# Altium schematic sheet OTL2_LED-Driver.SchDoc(OTL2_LED-Driver) (continued)

## Library data of the parts
5V_USB +3V3 +5V +48V DIM1 DIM2 RX TP1-TP11 TX = Test_Point: Library Name: josh-lib.SchLib
C1 C3 C5 = C1206 4.7uF 100V X7S: 100V 4.7uF X7S ±10% 1206 Multilayer Ceramic Capacitors MLCC - SMD/SMT ROHS; Manufacturer: Murata; Manufacturer Lifecycle Status: IN PRODUCTION; Manufacturer Part Number: GRM31CC72A475KE11L; Capacitance: 4.7µF; Case/Package: 1206; Category: Ceramic Capacitors; Component Kind: Standard; ComponentLink1Description: https://datasheet.octopart.com:443/GRM31CC72A475KE11L-Murata-datasheet-141280425.pdf?src-supplier=Geoflip; ComponentLink1URL: https://datasheet.octopart.com:443/GRM31CC72A475KE11L-Murata-datasheet-141280425.pdf?src-supplier=Geoflip; ComponentLink2Description: https://datasheet.octopart.com:443/GRM31CC72A475KE11L-Murata-datasheet-165579666.pdf?src-supplier=TME; ComponentLink2URL: https://datasheet.octopart.com:443/GRM31CC72A475KE11L-Murata-datasheet-165579666.pdf?src-supplier=TME; ComponentLink3Description: https://datasheet.octopart.com:443/GRM31CC72A475KE11L-Murata-datasheet-140709036.pdf?src-supplier=Ciiva; ComponentLink3URL: https://datasheet.octopart.com:443/GRM31CC72A475KE11L-Murata-datasheet-140709036.pdf?src-supplier=Ciiva; ComponentLink4Description: https://datasheet.octopart.com:443/GRM31CC72A475KE11L-Murata-datasheet-138746279.pdf?src-supplier=Ciiva; ComponentLink4URL: https://datasheet.octopart.com:443/GRM31CC72A475KE11L-Murata-datasheet-138746279.pdf?src-supplier=Ciiva; ComponentLink5Description: https://datasheet.octopart.com/GRM31CC72A475KE11L-Murata-datasheet-12515295.pdf; ComponentLink5URL: https://datasheet.octopart.com/GRM31CC72A475KE11L-Murata-datasheet-12515295.pdf; ComponentLink6Description: https://datasheet.octopart.com/GRM31CC72A475KE11L-Murata-datasheet-12510076.pdf; ComponentLink6URL: https://datasheet.octopart.com/GRM31CC72A475KE11L-Murata-datasheet-12510076.pdf; ComponentLink7Description: https://datasheet.octopart.com/GRM31CC72A475KE11L-Murata-datasheet-11978611.pdf; ComponentLink7URL: https://datasheet.octopart.com/GRM31CC72A475KE11L-Murata-datasheet-11978611.pdf; ComponentLink8Description: https://datasheet.octopart.com/GRM31CC72A475KE11L-Murata-datasheet-10025737.pdf; ComponentLink8URL: https://datasheet.octopart.com/GRM31CC72A475KE11L-Murata-datasheet-10025737.pdf; ComponentLink9Description: https://datasheet.octopart.com/GRM31CC72A475KE11L-Murata-datasheet-8819587.pdf; ComponentLink9URL: https://datasheet.octopart.com/GRM31CC72A475KE11L-Murata-datasheet-8819587.pdf; ComponentLink10Description: http://sigma.octopart.com:80/reach_statement/Murata-company-16.pdf?src-supplier=Compliance+Statements; ComponentLink10URL: http://sigma.octopart.com:80/reach_statement/Murata-company-16.pdf?src-supplier=Compliance+Statements; ComponentLink11Description: LCSC; ComponentLink11URL: https://jlcpcb.com/partdetail/236748-GRM31CC72A475KE11L/C237304; Dielectric: X7S; Dielectric Material: Ceramic; Export Control Classification Number (ECCN) Code: EAR99; Harmonized Tariff Schedule (HTS) Code: 8532.24.00.20; Height: 1.6mm; Introduction Date: 2017-02-22; Length: 3.2mm; Lifecycle Status: Production; Material: Ceramic; Max Operating Temperature: 125°C; Min Operating Temperature: -55°C; Mount: Surface Mount; Number of Terminals: 2; Pricing 1: 2000=0.16105 (USD); REACH SVHC: Yes; RoHS: Compliant; Schedule B: 8532240020, 8532240020|8532240020|8532240020|8532240020|8532240020|8532240020, 8532240020|8532240020|8532240020|8532240020|8532240020|8532240020|8532240020, 8532240020|8532240020|8532240020|8532240020|8532240020|8532240020|8532240020|8532240020; Stock 1: 66000; Supplier: LCSC; Supplier 1: Avnet; Supplier Part Number: C237304; Supplier Part Number 1: GRM31CC72A475KE11L; Thickness: 1.6mm; Tolerance: 10%; Voltage Rating (DC): 100V; Width: 1.6mm; Library Name: josh-lib.SchLib
C2 C4 C12 C16 C17 = CL05A105KA5NQNC: 1 µF ±10% 25V Ceramic Capacitor X5R 0402 (1005 Metric); Manufacturer: Samsung; Capacitance: 1uF; Case Code (Imperial): 0402; Case/Package: 0402; Category: Ceramic Capacitors; Component Kind: Standard; ComponentLink6Description: LCSC; ComponentLink6URL: https://lcsc.com/product-detail/Multilayer-Ceramic-Capacitors-MLCC-SMD-SMT_Samsung-Electro-Mechanics-CL05A105KA5NQNC_C52923.html; Depth: 0.5mm; Dielectric: X5R; Dielectric Material: Ceramic; Height: 0.5mm; Lead Free: Lead Free; Lead Pitch: 0.3mm; Length: 1mm; Manufacturer Part Number: CL05A105KA5NQNC; Max Operating Temperature: 85°C; Min Operating Temperature: -55°C; Mount: Surface Mount; Number of Pins: 2; Package Quantity: 1; Packaging: Tape and Reel; Radiation Hardening: No; REACH SVHC: No SVHC; RoHS: Yes; Supplier: LCSC; Supplier 1: Arrow Electronics; Supplier Part Number: C52923; Supplier Part Number 1: CL05A105KA5NQNC; Termination: SMD/SMT; Thickness: 0.022inch; Tolerance: 10%; Width: 0.02inch; Library Name: josh-lib.SchLib
  C12 C16 C17: Voltage Rating: 25V
C6 C10 C13 C14 C21 C22 = CL05B104KO5NNNC: 16V 100nF X7R ±10% 0402 Multilayer Ceramic Capacitors MLCC - SMD/SMT ROHS; Manufacturer: Samsung Electro-Mechanics; Capacitance: 100nF; Case Code (Imperial): 0402; Case Code (Metric): 1005; Case/Package: 0402; Category: Ceramic Capacitors; China RoHS: Compliant; Component Kind: Standard; ComponentLink1Description: https://datasheet.octopart.com:443/CL05B104KO5NNNC-Samsung-Electro-Mechanics-datasheet-181960518.pdf?src-supplier=IHS; ComponentLink1URL: https://datasheet.octopart.com:443/CL05B104KO5NNNC-Samsung-Electro-Mechanics-datasheet-181960518.pdf?src-supplier=IHS; ComponentLink2Description: https://datasheet.octopart.com:443/CL05B104KO5NNNC-Samsung-Electro-Mechanics-datasheet-81461623.pdf?src-supplier=Upverter; ComponentLink2URL: https://datasheet.octopart.com:443/CL05B104KO5NNNC-Samsung-Electro-Mechanics-datasheet-81461623.pdf?src-supplier=Upverter; ComponentLink3Description: https://datasheet.octopart.com/CL05B104KO5NNNC-Samsung-Electro-Mechanics-datasheet-8324245.pdf; ComponentLink3URL: https://datasheet.octopart.com/CL05B104KO5NNNC-Samsung-Electro-Mechanics-datasheet-8324245.pdf; ComponentLink4Description: https://datasheet.octopart.com/CL05B104KO5NNNC-Samsung-Electro-Mechanics-datasheet-97040617.pdf; ComponentLink4URL: https://datasheet.octopart.com/CL05B104KO5NNNC-Samsung-Electro-Mechanics-datasheet-97040617.pdf; ComponentLink5Description: https://datasheet.octopart.com:443/CL05B104KO5NNNC-Samsung-Electro-Mechanics-datasheet-141346863.pdf?src-supplier=Samsung+SCT; ComponentLink5URL: https://datasheet.octopart.com:443/CL05B104KO5NNNC-Samsung-Electro-Mechanics-datasheet-141346863.pdf?src-supplier=Samsung+SCT; ComponentLink6Description: https://datasheet.octopart.com:443/CL05B104KO5NNNC-Samsung-datasheet-151956582.pdf?src-supplier=SOS+electronic; ComponentLink6URL: https://datasheet.octopart.com:443/CL05B104KO5NNNC-Samsung-datasheet-151956582.pdf?src-supplier=SOS+electronic; ComponentLink7Description: https://datasheet.octopart.com:443/CL05B104KO5NNNC-Samsung-datasheet-42485123.pdf?src-supplier=Future+Electronics; ComponentLink7URL: https://datasheet.octopart.com:443/CL05B104KO5NNNC-Samsung-datasheet-42485123.pdf?src-supplier=Future+Electronics; ComponentLink8Description: https://datasheet.octopart.com:443/CL05B104KO5NNNC-Samsung-datasheet-34153835.pdf?src-supplier=Future+Electronics; ComponentLink8URL: https://datasheet.octopart.com:443/CL05B104KO5NNNC-Samsung-datasheet-34153835.pdf?src-supplier=Future+Electronics; ComponentLink9Description: https://datasheet.octopart.com:443/CL05B104KO5NNNC-Samsung-datasheet-26589024.pdf?src-supplier=Future+Electronics; ComponentLink9URL: https://datasheet.octopart.com:443/CL05B104KO5NNNC-Samsung-datasheet-26589024.pdf?src-supplier=Future+Electronics; ComponentLink10Description: https://datasheet.octopart.com:443/CL05B104KO5NNNC-Samsung-Electro-Mechanics-datasheet-149136714.pdf?src-supplier=Future+Electronics; ComponentLink10URL: https://datasheet.octopart.com:443/CL05B104KO5NNNC-Samsung-Electro-Mechanics-datasheet-149136714.pdf?src-supplier=Future+Electronics; ComponentLink11Description: https://datasheet.octopart.com:443/CL05B104KO5NNNC-Samsung-datasheet-59220082.pdf?src-supplier=TME; ComponentLink11URL: https://datasheet.octopart.com:443/CL05B104KO5NNNC-Samsung-datasheet-59220082.pdf?src-supplier=TME; ComponentLink12Description: LCSC; ComponentLink12URL: https://jlcpcb.com/partdetail/1877-CL05B104KO5NNNC/C1525; Contact Plating: Tin; Depth: 500µm; Dielectric: X7R; Dielectric Material: Ceramic; Height: 500µm; Lead Free: Lead Free; Length: 1mm; Lifecycle Status: Production; Manufacturer Part Number: CL05B104KO5NNNC; Material: Ceramic; Max Operating Temperature: 125°C; Min Operating Temperature: -55°C; Mount: Surface Mount; Number of Terminals: 2; Pricing 1: 10000=0.00139, 20000=0.00137, 40000=0.00135, 80000=0.00133, 160000=0.00131 (USD); Radiation Hardening: No; REACH SVHC: Yes; RoHS: Compliant; Schedule B: 8532240020, 8532240020|8532240020|8532240020|8532240020|8532240020|8532240020|8532240020|8532240020|8532240020|8532240020|8532240020|8532240020|8532240020|8532240020|8532240020|8532240020|8532240020|8532240020|8532240020|8532240020|8532240020|8532240020|8532240020|85; Stock 1: 1520000; Supplier: LCSC; Supplier 1: Avnet; Supplier Part Number: C1525; Supplier Part Number 1: CL05B104KO5NNNC; Thickness: 558.8µm; Tolerance: 10%; Voltage: 16V; Voltage Rating: 16V; Voltage Rating (DC): 16V; Width: 500µm; Library Name: josh-lib.SchLib
C7 = CA45-A-16V-10μF-K: 10uF 16V 2Ω@100kHz ±10% CASE-A-3216 Tantalum Capacitors ROHS; Manufacturer: TORCH; Value: 10uF 16V CASE-A-3216; Component Kind: Standard; ComponentLink1Description: LCSC; ComponentLink1URL: https://www.lcsc.com/product-detail/Tantalum-Capacitors_TORCH-CA45-A-16V-10-F-K_C185774.html; Manufacturer Part Number: CA45-A-16V-10μF-K; Supplier: LCSC; Supplier Part Number: C185774; Library Name: josh-lib.SchLib
C8 C9 = C0805 22uF 25V X5R: Multilayer Ceramic Capacitor, 22 uF, 25 V, ± 20%, X5R, 0805 [2012 Metric]; Manufacturer: Samsung Electro-Mechanics; Manufacturer Part Number: CL21A226MAQNNNE; Capacitance: 22µF; Voltage Rating: 25V; Case Code (Imperial): 0805; Case Code (Metric): 2012; Case/Package: 0805; Category: Ceramic Capacitors; China RoHS: Compliant; Component Kind: Standard; ComponentLink1Description: https://datasheet.octopart.com:443/CL21A226MAQNNNE-Samsung-Electro-Mechanics-datasheet-182022794.pdf?src-supplier=IHS; ComponentLink1URL: https://datasheet.octopart.com:443/CL21A226MAQNNNE-Samsung-Electro-Mechanics-datasheet-182022794.pdf?src-supplier=IHS; ComponentLink2Description: https://datasheet.octopart.com/CL21A226MAQNNNE-Samsung-Electro-Mechanics-datasheet-97040617.pdf; ComponentLink2URL: https://datasheet.octopart.com/CL21A226MAQNNNE-Samsung-Electro-Mechanics-datasheet-97040617.pdf; ComponentLink3Description: https://datasheet.octopart.com/CL21A226MAQNNNE-Samsung-Electro-Mechanics-datasheet-8324245.pdf; ComponentLink3URL: https://datasheet.octopart.com/CL21A226MAQNNNE-Samsung-Electro-Mechanics-datasheet-8324245.pdf; ComponentLink4Description: https://datasheet.octopart.com/General-Multilayer-Ceramic-Capacitors-Samsung-datasheet-family-111.pdf; ComponentLink4URL: https://datasheet.octopart.com/General-Multilayer-Ceramic-Capacitors-Samsung-datasheet-family-111.pdf; ComponentLink5Description: https://datasheet.octopart.com:443/CL21A226MAQNNNE-Samsung-Electro-Mechanics-datasheet-87580133.pdf?src-supplier=Future+Electronics; ComponentLink5URL: https://datasheet.octopart.com:443/CL21A226MAQNNNE-Samsung-Electro-Mechanics-datasheet-87580133.pdf?src-supplier=Future+Electronics; ComponentLink6Description: https://datasheet.octopart.com:443/CL21A226MAQNNNE-Samsung-Electro-Mechanics-datasheet-81462139.pdf?src-supplier=Future+Electronics; ComponentLink6URL: https://datasheet.octopart.com:443/CL21A226MAQNNNE-Samsung-Electro-Mechanics-datasheet-81462139.pdf?src-supplier=Future+Electronics; ComponentLink7Description: https://datasheet.octopart.com:443/CL21A226MAQNNNE-Samsung-datasheet-66660282.pdf?src-supplier=Future+Electronics; ComponentLink7URL: https://datasheet.octopart.com:443/CL21A226MAQNNNE-Samsung-datasheet-66660282.pdf?src-supplier=Future+Electronics; ComponentLink8Description: https://datasheet.octopart.com:443/CL21A226MAQNNNE-Samsung-datasheet-48647558.pdf?src-supplier=Future+Electronics; ComponentLink8URL: https://datasheet.octopart.com:443/CL21A226MAQNNNE-Samsung-datasheet-48647558.pdf?src-supplier=Future+Electronics; ComponentLink9Description: https://datasheet.octopart.com:443/CL21A226MAQNNNE-Samsung-datasheet-27135701.pdf?src-supplier=Future+Electronics; ComponentLink9URL: https://datasheet.octopart.com:443/CL21A226MAQNNNE-Samsung-datasheet-27135701.pdf?src-supplier=Future+Electronics; ComponentLink10Description: https://datasheet.octopart.com:443/CL21A226MAQNNNE-Samsung-Electro-Mechanics-datasheet-149154242.pdf?src-supplier=Future+Electronics; ComponentLink10URL: https://datasheet.octopart.com:443/CL21A226MAQNNNE-Samsung-Electro-Mechanics-datasheet-149154242.pdf?src-supplier=Future+Electronics; ComponentLink11Description: https://datasheet.octopart.com:443/CL21A226MAQNNNE-Samsung-datasheet-59220082.pdf?src-supplier=TME; ComponentLink11URL: https://datasheet.octopart.com:443/CL21A226MAQNNNE-Samsung-datasheet-59220082.pdf?src-supplier=TME; ComponentLink12Description: http://sigma.octopart.com:80/rohs_certificate/General-Multilayer-Ceramic-Capacitors-Samsung-family-110.pdf?src-supplier=Compliance+Statements; ComponentLink12URL: http://sigma.octopart.com:80/rohs_certificate/General-Multilayer-Ceramic-Capacitors-Samsung-family-110.pdf?src-supplier=Compliance+Statements; ComponentLink13Description: http://sigma.octopart.com:80/rohs_statement/General-Multilayer-Ceramic-Capacitors-Samsung-family-132.pdf?src-supplier=Compliance+Statements; ComponentLink13URL: http://sigma.octopart.com:80/rohs_statement/General-Multilayer-Ceramic-Capacitors-Samsung-family-132.pdf?src-supplier=Compliance+Statements; ComponentLink14Description: http://sigma.octopart.com:80/reach_statement/General-Multilayer-Ceramic-Capacitors-Samsung-family-133.pdf?src-supplier=Compliance+Statements; ComponentLink14URL: http://sigma.octopart.com:80/reach_statement/General-Multilayer-Ceramic-Capacitors-Samsung-family-133.pdf?src-supplier=Compliance+Statements; ComponentLink15Description: LCSC; ComponentLink15URL: https://jlcpcb.com/partdetail/46786-CL21A226MAQNNNE/C45783; Contact Plating: Tin; Country of Origin: Mainland China, Philippines, South Korea; Depth: 1.25mm; Dielectric: X5R; Dielectric Material: Ceramic; Export Control Classification Number (ECCN) Code: EAR99; Harmonized Tariff Schedule (HTS) Code: 8532.24.00.20; Height: 1.25mm; Introduction Date: 2012-03-06; Length: 2mm; Lifecycle Status: NRND; Max Operating Temperature: 85°C; Min Operating Temperature: -55°C; Mount: Surface Mount; Number of Terminals: 2; Packaging: Cut Tape (CT); Pricing 1: 5=0.107, 50=0.0619, 250=0.0615, 500=0.0611, 1000=0.0607, 2000=0.0603 (GBP); REACH SVHC: Yes; RoHS: Compliant; Supplier: LCSC; Supplier 1: Farnell; Supplier Part Number: C45783; Supplier Part Number 1: 3013459; Termination: Wraparound; Thickness: 1.397mm; Tolerance: 20%; Voltage Rating (DC): 25V; Width: 1.25mm; Library Name: josh-lib.SchLib
C11 = CL21A226MOQNNNE: Cap Ceramic 22uF 16V X5R 20% SMD 0805 85°C Embossed Plastic T/R; Manufacturer: Samsung; Capacitance: 22uF; Case Code (Imperial): 0805; Case Code (Metric): 2012; Case/Package: 0805; Category: Ceramic Capacitors; Component Kind: Standard; ComponentLink1Description: https://datasheet.ciiva.com/25724/cl21a226moqnnne-25724832.pdf?src-supplier=Digi-Key; ComponentLink1URL: https://datasheet.ciiva.com/25724/cl21a226moqnnne-25724832.pdf?src-supplier=Digi-Key; ComponentLink2Description: LCSC; ComponentLink2URL: https://www.lcsc.com/product-detail/Multilayer-Ceramic-Capacitors-MLCC-SMD-SMT_Samsung-Electro-Mechanics-CL21A226MOQNNNE_C98190.html; Dielectric: X5R; Height: 1.45mm; Lead Free: Lead Free; Length: 0.079inch; Manufacturer Part Number: CL21A226MOQNNNE; Material: Ceramic; Max Operating Temperature: 85°C; Min Operating Temperature: -55°C; Mount: Surface Mount; Package Quantity: 1; Packaging: Cut Tape; Pricing 1: 2000=0.052, 4000=0.0513, 10000=0.0472, 14000=0.0431, 50000=0.0375, 100000=0.0327 (USD); RoHS: Yes; RoHS Compliant: Yes; Series: CL; Stock 1: 884000; Supplier: LCSC; Supplier 1: Arrow Electronics; Supplier Part Number: C98190; Supplier Part Number 1: CL21A226MOQNNNE; Thickness: 0.055inch; Tolerance: 20%; Value: 22uF 16V X5R; Voltage Rating: 16V; Voltage Rating (DC): 16V; Width: 0.049inch; Library Name: josh-lib.SchLib
C15 = C0402 10nF 50V X7R JLC: 50V 10nF X7R ±10% 0402 Multilayer Ceramic Capacitors MLCC - SMD/SMT ROHS; Manufacturer: Samsung Electro-Mechanics; Manufacturer Part Number: CL05B103KB5NNNC; Capacitance: 10nF; Case Code (Imperial): 0402; Case Code (Metric): 1005; Case/Package: 0402; Category: Ceramic Capacitors; China RoHS: Compliant; Component Kind: Standard; ComponentLink1Description: https://datasheet.octopart.com:443/CL05B103KB5NNNC-Samsung-Electro-Mechanics-datasheet-136482191.pdf?src-supplier=IHS; ComponentLink1URL: https://datasheet.octopart.com:443/CL05B103KB5NNNC-Samsung-Electro-Mechanics-datasheet-136482191.pdf?src-supplier=IHS; ComponentLink2Description: https://datasheet.octopart.com/CL05B103KB5NNNC-Samsung-Electro-Mechanics-datasheet-8324245.pdf; ComponentLink2URL: https://datasheet.octopart.com/CL05B103KB5NNNC-Samsung-Electro-Mechanics-datasheet-8324245.pdf; ComponentLink3Description: https://datasheet.octopart.com/CL05B103KB5NNNC-Samsung-Electro-Mechanics-datasheet-97040617.pdf; ComponentLink3URL: https://datasheet.octopart.com/CL05B103KB5NNNC-Samsung-Electro-Mechanics-datasheet-97040617.pdf; ComponentLink4Description: https://datasheet.octopart.com:443/CL05B103KB5NNNC-Samsung-Electro-Mechanics-datasheet-141346848.pdf?src-supplier=Samsung+SCT; ComponentLink4URL: https://datasheet.octopart.com:443/CL05B103KB5NNNC-Samsung-Electro-Mechanics-datasheet-141346848.pdf?src-supplier=Samsung+SCT; ComponentLink5Description: https://datasheet.octopart.com:443/CL05B103KB5NNNC-Samsung-Electro-Mechanics-datasheet-117987511.pdf?src-supplier=Future+Electronics; ComponentLink5URL: https://datasheet.octopart.com:443/CL05B103KB5NNNC-Samsung-Electro-Mechanics-datasheet-117987511.pdf?src-supplier=Future+Electronics; ComponentLink6Description: https://datasheet.octopart.com:443/CL05B103KB5NNNC-Samsung-Electro-Mechanics-datasheet-81461618.pdf?src-supplier=Future+Electronics; ComponentLink6URL: https://datasheet.octopart.com:443/CL05B103KB5NNNC-Samsung-Electro-Mechanics-datasheet-81461618.pdf?src-supplier=Future+Electronics; ComponentLink7Description: https://datasheet.octopart.com:443/CL05B103KB5NNNC-Samsung-datasheet-44382835.pdf?src-supplier=Future+Electronics; ComponentLink7URL: https://datasheet.octopart.com:443/CL05B103KB5NNNC-Samsung-datasheet-44382835.pdf?src-supplier=Future+Electronics; ComponentLink8Description: https://datasheet.octopart.com:443/CL05B103KB5NNNC-Samsung-datasheet-26589021.pdf?src-supplier=Future+Electronics; ComponentLink8URL: https://datasheet.octopart.com:443/CL05B103KB5NNNC-Samsung-datasheet-26589021.pdf?src-supplier=Future+Electronics; ComponentLink9Description: https://datasheet.octopart.com:443/CL05B103KB5NNNC-Samsung-Electro-Mechanics-datasheet-149136708.pdf?src-supplier=Future+Electronics; ComponentLink9URL: https://datasheet.octopart.com:443/CL05B103KB5NNNC-Samsung-Electro-Mechanics-datasheet-149136708.pdf?src-supplier=Future+Electronics; ComponentLink10Description: https://datasheet.octopart.com:443/CL05B103KB5NNNC-Samsung-datasheet-59220082.pdf?src-supplier=TME; ComponentLink10URL: https://datasheet.octopart.com:443/CL05B103KB5NNNC-Samsung-datasheet-59220082.pdf?src-supplier=TME; ComponentLink11Description: https://datasheet.octopart.com:443/CL05B103KB5NNNC-Samsung-Electro-Mechanics-datasheet-11773140.pdf?src-supplier=Arrow+Electronics; ComponentLink11URL: https://datasheet.octopart.com:443/CL05B103KB5NNNC-Samsung-Electro-Mechanics-datasheet-11773140.pdf?src-supplier=Arrow+Electronics; ComponentLink12Description: JLCPCB; ComponentLink12URL: https://jlcpcb.com/partdetail/15869-CL05B103KB5NNNC/C15195; Contact Plating: Tin; Depth: 500µm; Dielectric: X7R; Dielectric Material: Ceramic; Height: 550µm; Lead Free: Lead Free; Length: 1mm; Lifecycle Status: Production; Material: Ceramic; Max Operating Temperature: 125°C; Min Operating Temperature: -55°C; Mount: Surface Mount; Number of Terminals: 2; Pricing 1: 80000=0.0013 (USD); Radiation Hardening: No; REACH SVHC: Yes; RoHS: Compliant; Schedule B: 8532240020, 8532240020|8532240020, 8532240020|8532240020|8532240020, 8532240020|8532240020|8532240020|8532240020; Stock 1: 9520000; Supplier: LCSC; Supplier 1: Arrow Electronics; Supplier Part Number: C15195; Supplier Part Number 1: CL05B103KB5NNNC; Thickness: 558.8µm; Tolerance: 10%; Voltage: 50V; Voltage Rating: 50V; Voltage Rating (DC): 50V; Width: 500µm; Library Name: josh-lib.SchLib
C18 = C0805 100nF 100V X7R: 100V 100nF X7R ±10% 0805 Multilayer Ceramic Capacitors MLCC - SMD/SMT ROHS; Manufacturer: Samsung Electro-Mechanics; Manufacturer Part Number: CL21B104KCFNNNE; Capacitance: 100nF; Case Code (Imperial): 0805; Case Code (Metric): 2012; Case/Package: 0805; Category: Ceramic Capacitors; China RoHS: Compliant; Component Kind: Standard; ComponentLink1Description: https://datasheet.octopart.com:443/CL21B104KCFNNNE-Samsung-Electro-Mechanics-datasheet-136482490.pdf?src-supplier=IHS; ComponentLink1URL: https://datasheet.octopart.com:443/CL21B104KCFNNNE-Samsung-Electro-Mechanics-datasheet-136482490.pdf?src-supplier=IHS; ComponentLink2Description: https://datasheet.octopart.com:443/CL21B104KCFNNNE-Samsung-Electro-Mechanics-datasheet-116891036.pdf?src-supplier=Future+Electronics; ComponentLink2URL: https://datasheet.octopart.com:443/CL21B104KCFNNNE-Samsung-Electro-Mechanics-datasheet-116891036.pdf?src-supplier=Future+Electronics; ComponentLink3Description: https://datasheet.octopart.com:443/CL21B104KCFNNNE-Samsung-Electro-Mechanics-datasheet-68302338.pdf?src-supplier=Future+Electronics; ComponentLink3URL: https://datasheet.octopart.com:443/CL21B104KCFNNNE-Samsung-Electro-Mechanics-datasheet-68302338.pdf?src-supplier=Future+Electronics; ComponentLink4Description: https://datasheet.octopart.com:443/CL21B104KCFNNNE-Samsung-datasheet-66660282.pdf?src-supplier=Future+Electronics; ComponentLink4URL: https://datasheet.octopart.com:443/CL21B104KCFNNNE-Samsung-datasheet-66660282.pdf?src-supplier=Future+Electronics; ComponentLink5Description: https://datasheet.octopart.com:443/CL21B104KCFNNNE-Samsung-Electro-Mechanics-datasheet-149045942.pdf?src-supplier=Future+Electronics; ComponentLink5URL: https://datasheet.octopart.com:443/CL21B104KCFNNNE-Samsung-Electro-Mechanics-datasheet-149045942.pdf?src-supplier=Future+Electronics; ComponentLink6Description: https://datasheet.octopart.com:443/CL21B104KCFNNNE-Samsung-datasheet-37081328.pdf?src-supplier=Future+Electronics; ComponentLink6URL: https://datasheet.octopart.com:443/CL21B104KCFNNNE-Samsung-datasheet-37081328.pdf?src-supplier=Future+Electronics; ComponentLink7Description: https://datasheet.octopart.com/CL21B104KCFNNNE-Samsung-Electro-Mechanics-datasheet-97040617.pdf; ComponentLink7URL: https://datasheet.octopart.com/CL21B104KCFNNNE-Samsung-Electro-Mechanics-datasheet-97040617.pdf; ComponentLink8Description: https://datasheet.octopart.com/CL21B104KCFNNNE-Samsung-Electro-Mechanics-datasheet-8324245.pdf; ComponentLink8URL: https://datasheet.octopart.com/CL21B104KCFNNNE-Samsung-Electro-Mechanics-datasheet-8324245.pdf; ComponentLink9Description: https://datasheet.octopart.com:443/CL21B104KCFNNNE-Samsung-datasheet-8326407.pdf?src-supplier=TME; ComponentLink9URL: https://datasheet.octopart.com:443/CL21B104KCFNNNE-Samsung-datasheet-8326407.pdf?src-supplier=TME; ComponentLink10Description: LCSC; ComponentLink10URL: https://jlcpcb.com/partdetail/28983-CL21B104KCFNNNE/C28233; Depth: 1.25mm; Dielectric: X7R; Dielectric Material: Ceramic; Height: 1.25mm; Length: 2mm; Lifecycle Status: Production; Material: Ceramic; Max Operating Temperature: 125°C; Min Operating Temperature: -55°C; Mount: Surface Mount; Number of Terminals: 2; Packaging: Tape and Reel; Pricing 1: 2000=0.00506, 4000=0.00498, 8000=0.00495, 16000=0.00492, 32000=0.0049 (USD); Radiation Hardening: No; REACH SVHC: Yes; RoHS: Compliant; Schedule B: 8532240020, 8532240020|8532240020, 8532240020|8532240020|8532240020, 8532240020|8532240020|8532240020|8532240020; Stock 1: 1668000; Supplier: LCSC; Supplier 1: Avnet; Supplier Part Number: C28233; Supplier Part Number 1: CL21B104KCFNNNE; Thickness: 1.3462mm; Tolerance: 10%; Voltage Rating (DC): 100V; Width: 1.25mm; Library Name: josh-lib.SchLib
C19 C20 = C0805 220nF 100V X7R 10%: CAP CER 0.22UF 100V X7R 0805; Manufacturer: Yageo; Manufacturer Part Number: CC0805KKX7R0BB224; Tolerance: 10%; Capacitance: 220nF; Case Code (Imperial): 0805; Case Code (Metric): 2012; Case/Package: 0805; Category: Ceramic Capacitors; Component Kind: Standard; ComponentLink1Description: http://www.mouser.com/ds/2/447/Yageo_UPY-GP_NP0_16V-to-50V_9-358835.pdf?src-supplier=Mouser; ComponentLink1URL: http://www.mouser.com/ds/2/447/Yageo_UPY-GP_NP0_16V-to-50V_9-358835.pdf?src-supplier=Mouser; ComponentLink2Description: https://media.digikey.com/pdf/Data%20Sheets/Yageo%20PDFs/NPO,%20X7R%20Mid-voltage.pdf?src-supplier=Digi-Key; ComponentLink2URL: https://media.digikey.com/pdf/Data%20Sheets/Yageo%20PDFs/NPO,%20X7R%20Mid-voltage.pdf?src-supplier=Digi-Key; ComponentLink3Description: http://www.yageo.com/documents/recent/UPY-NP0X7R_MV_100-to-630V_21.pdf?src-supplier=Digi-Key; ComponentLink3URL: http://www.yageo.com/documents/recent/UPY-NP0X7R_MV_100-to-630V_21.pdf?src-supplier=Digi-Key; ComponentLink4Description: LCSC; ComponentLink4URL: https://www.lcsc.com/product-detail/Multilayer-Ceramic-Capacitors-MLCC-SMD-SMT_YAGEO-CC0805KKX7R0BB224_C513710.html; Composition: Ceramic; Dielectric: X7R; Length: 0.079inch; Max Operating Temperature: 125°C; Min Operating Temperature: -55°C; Mount: Surface Mount; Package Quantity: 3000; Packaging: Tape and Reel; Pricing 1: 1=0.34000, 10=0.23300, 100=0.13190, 500=0.09308, 1000=0.08145 (USD); RoHS: Yes; Stock 1: 72267; Supplier: LCSC; Supplier 1: Digi-Key; Supplier Part Number: C513710; Supplier Part Number 1: 311-1874-1-ND; Termination: SMD/SMT; Thickness: 0.028inch; Voltage Rating: 100V; Voltage Rating (DC): 100V; Weight: 0.000194oz; Width: 0.049inch; Library Name: josh-lib.SchLib
C23 = CL10A106KP8NNNC: 10V 10uF X5R ±10% 0603 Multilayer Ceramic Capacitors MLCC - SMD/SMT ROHS; Manufacturer: Samsung Electro-Mechanics; Capacitance: 10µF; Case Code (Imperial): 0603; Case Code (Metric): 1608; Case/Package: 0603; Category: Ceramic Capacitors; China RoHS: Compliant; Component Kind: Standard; ComponentLink1Description: https://datasheet.octopart.com:443/CL10A106KP8NNNC-Samsung-Electro-Mechanics-datasheet-181960519.pdf?src-supplier=Newark; ComponentLink1URL: https://datasheet.octopart.com:443/CL10A106KP8NNNC-Samsung-Electro-Mechanics-datasheet-181960519.pdf?src-supplier=Newark; ComponentLink2Description: https://datasheet.octopart.com/CL10A106KP8NNNC-Samsung-Electro-Mechanics-datasheet-8324245.pdf; ComponentLink2URL: https://datasheet.octopart.com/CL10A106KP8NNNC-Samsung-Electro-Mechanics-datasheet-8324245.pdf; ComponentLink3Description: https://datasheet.octopart.com/CL10A106KP8NNNC-Samsung-Electro-Mechanics-datasheet-97040617.pdf; ComponentLink3URL: https://datasheet.octopart.com/CL10A106KP8NNNC-Samsung-Electro-Mechanics-datasheet-97040617.pdf; ComponentLink4Description: https://datasheet.octopart.com:443/CL10A106KP8NNNC-Samsung-Electro-Mechanics-datasheet-136031754.pdf?src-supplier=Future+Electronics; ComponentLink4URL: https://datasheet.octopart.com:443/CL10A106KP8NNNC-Samsung-Electro-Mechanics-datasheet-136031754.pdf?src-supplier=Future+Electronics; ComponentLink5Description: https://datasheet.octopart.com:443/CL10A106KP8NNNC-Samsung-Electro-Mechanics-datasheet-110226289.pdf?src-supplier=Future+Electronics; ComponentLink5URL: https://datasheet.octopart.com:443/CL10A106KP8NNNC-Samsung-Electro-Mechanics-datasheet-110226289.pdf?src-supplier=Future+Electronics; ComponentLink6Description: https://datasheet.octopart.com:443/CL10A106KP8NNNC-Samsung-Electro-Mechanics-datasheet-81461787.pdf?src-supplier=Future+Electronics; ComponentLink6URL: https://datasheet.octopart.com:443/CL10A106KP8NNNC-Samsung-Electro-Mechanics-datasheet-81461787.pdf?src-supplier=Future+Electronics; ComponentLink7Description: https://datasheet.octopart.com:443/CL10A106KP8NNNC-Samsung-datasheet-48647232.pdf?src-supplier=Future+Electronics; ComponentLink7URL: https://datasheet.octopart.com:443/CL10A106KP8NNNC-Samsung-datasheet-48647232.pdf?src-supplier=Future+Electronics; ComponentLink8Description: https://datasheet.octopart.com:443/CL10A106KP8NNNC-Samsung-datasheet-34157262.pdf?src-supplier=Future+Electronics; ComponentLink8URL: https://datasheet.octopart.com:443/CL10A106KP8NNNC-Samsung-datasheet-34157262.pdf?src-supplier=Future+Electronics; ComponentLink9Description: https://datasheet.octopart.com:443/CL10A106KP8NNNC-Samsung-datasheet-26589120.pdf?src-supplier=Future+Electronics; ComponentLink9URL: https://datasheet.octopart.com:443/CL10A106KP8NNNC-Samsung-datasheet-26589120.pdf?src-supplier=Future+Electronics; ComponentLink10Description: https://datasheet.octopart.com:443/CL10A106KP8NNNC-Samsung-Electro-Mechanics-datasheet-149156481.pdf?src-supplier=Future+Electronics; ComponentLink10URL: https://datasheet.octopart.com:443/CL10A106KP8NNNC-Samsung-Electro-Mechanics-datasheet-149156481.pdf?src-supplier=Future+Electronics; ComponentLink11Description: https://datasheet.octopart.com:443/CL10A106KP8NNNC-Samsung-Electro-Mechanics-datasheet-11968064.pdf?src-supplier=Arrow+Electronics; ComponentLink11URL: https://datasheet.octopart.com:443/CL10A106KP8NNNC-Samsung-Electro-Mechanics-datasheet-11968064.pdf?src-supplier=Arrow+Electronics; ComponentLink12Description: https://datasheet.octopart.com:443/CL10A106KP8NNNC-Samsung-datasheet-8326407.pdf?src-supplier=TME; ComponentLink12URL: https://datasheet.octopart.com:443/CL10A106KP8NNNC-Samsung-datasheet-8326407.pdf?src-supplier=TME; ComponentLink13Description: JLCPCB; ComponentLink13URL: https://jlcpcb.com/partdetail/20411-CL10A106KP8NNNC/C19702; Contact Plating: Tin; Country of Origin: Mainland China, Philippines, South Korea; Depth: 800µm; Dielectric: X5R; Dielectric Material: Ceramic; Export Control Classification Number (ECCN) Code: EAR99; Harmonized Tariff Schedule (HTS) Code: 8532.24.00.20; Height: 800µm; Introduction Date: 2009-10-27; Lead Free: Lead Free; Length: 1.6mm; Lifecycle Status: Production; Manufacturer Part Number: CL10A106KP8NNNC; Material: Ceramic; Max Operating Temperature: 85°C; Min Operating Temperature: -55°C; Mount: Surface Mount; Number of Terminals: 2; Pricing 1: 4000=0.01797, 8000=0.01761, 16000=0.01726, 32000=0.01693, 64000=0.01661 (USD); Radiation Hardening: No; REACH SVHC: Yes; RoHS: Compliant; Schedule B: 8532240020, 8532240020|8532240020|8532240020|8532240020|8532240020|8532240020|8532240020|8532240020|8532240020|8532240020|8532240020|8532240020|8532240020|8532240020|8532240020|8532240020|8532240020|8532240020|8532240020|8532240020|8532240020|8532240020|8532240020|85; Stock 1: 484000; Supplier: LCSC; Supplier 1: Avnet; Supplier Part Number: C19702; Supplier Part Number 1: CL10A106KP8NNNC; Thickness: 889µm; Tolerance: 10%; Voltage Rating: 10V; Voltage Rating (DC): 10V; Width: 800µm; Library Name: josh-lib.SchLib
CONN1 = TYPE-C 16P QTWT: 3A 1 Surface Mount 16P Female -25℃~+85℃ Type-C SMD USB Connectors ROHS  USB-C; Manufacturer: SHOU HAN; Component Kind: Standard; ComponentLink1Description: LCSC; ComponentLink1URL: https://www.lcsc.com/product-detail/USB-Connectors_SHOU-HAN-TYPE-C-16P-QTWT_C5187472.html; Manufacturer Part Number: TYPE-C 16P QTWT; Supplier: LCSC; Supplier Part Number: C5187472; Value: USB-C; Library Name: josh-lib.SchLib
CONN2 CONN5 CONN6 = 781710002: Pico-EZmate Wire-to-Board Header Vertical Housing Height 1.55mm with Side Friction Locks 2 Circuits; Manufacturer: Molex; Manufacturer Part Number: 78171-0002; Contact Resistance: 20mR; Current Rating: 1.5A; Gender: 29Gender; Glow Wire Compliant: 16Compliance; Max Voltage Rating (DC): 5.05kV; Number of Circuits: 2; Pitch: 1.2mm; Voltage Rating: 50V; Category: Headers and Wire Housings; Component Kind: Standard; ComponentLink1Description: https://datasheet.ciiva.com/14156/78171-en-datasheet-14156253.pdf?src-supplier=Distrelec; ComponentLink1URL: https://datasheet.ciiva.com/14156/78171-en-datasheet-14156253.pdf?src-supplier=Distrelec; ComponentLink2Description: https://datasheet.ciiva.com/5152/0781710002-pcb-headers-152473-5152484.pdf?src-supplier=Mouser; ComponentLink2URL: https://datasheet.ciiva.com/5152/0781710002-pcb-headers-152473-5152484.pdf?src-supplier=Mouser; ComponentLink3Description: https://datasheet.ciiva.com/11748/0900766b80dca491-11748840.pdf?src-supplier=Rs; ComponentLink3URL: https://datasheet.ciiva.com/11748/0900766b80dca491-11748840.pdf?src-supplier=Rs; ComponentLink4Description: DigiKey; ComponentLink4URL: https://www.digikey.com/en/products/detail/molex/0781710002/2424924; Contact Gender: Female; Contact Material: Copper Alloy,Copper,Gold; Contact Plating: Gold; Halogen Free: 5696HalogenFree; Housing Material: Liquid Crystal Polymer; Max Operating Temperature: 85°C; Min Operating Temperature: -25°C; Mount: Surface Mount; Number of Contacts: 2; Number of Positions: 2; Number of Rows: 1; Orientation: Vertical; Package Quantity: 36000; Packaging: Tape and Reel; Pricing 1: 1=0.31, 10=0.249, 50=0.249, 100=0.203, 1000=0.169, 10000=0.15 (USD); Reach SVHC Compliant: Unknown; RoHS: Yes; RoHS Compliant: Yes; Series: Pico-Ezmate; Stock 1: 147997; Supplier 1: Mouser; Supplier Part Number 1: 538-78171-0002; Termination: Solder; Voltage Rating (AC): 50V; Library Name: josh-lib.SchLib
CONN3 = 009276004021106: Rectangular Power Connector, 4 Contact(s), Female, Surface Mount Terminal; Manufacturer: KYOCERA AVX; Current: 6A; Gender: Receptacle; Max Supply Voltage: 250V; Number of Circuits: 4; Number of Levels: 1; Pitch: 2.4892mm; Wire Gauge (Max): 24AWG; Wire Gauge (Min): 18AWG; Category: Power Connectors; Color: White; Component Kind: Standard; ComponentLink1Description: https://datasheet.octopart.com:443/009276004021106-KYOCERA-AVX-datasheet-180535296.pdf?src-supplier=IHS; ComponentLink1URL: https://datasheet.octopart.com:443/009276004021106-KYOCERA-AVX-datasheet-180535296.pdf?src-supplier=IHS; ComponentLink2Description: https://datasheet.octopart.com:443/009276004021106-AVX-datasheet-10129523.pdf?src-supplier=Newark; ComponentLink2URL: https://datasheet.octopart.com:443/009276004021106-AVX-datasheet-10129523.pdf?src-supplier=Newark; ComponentLink3Description: https://datasheet.octopart.com:443/009276004021106-KYOCERA-AVX-datasheet-57790735.pdf?src-supplier=Newark; ComponentLink3URL: https://datasheet.octopart.com:443/009276004021106-KYOCERA-AVX-datasheet-57790735.pdf?src-supplier=Newark; ComponentLink4Description: https://datasheet.octopart.com:443/00-9276-004-021-106-AVX-datasheet-32784765.pdf?src-supplier=Newark; ComponentLink4URL: https://datasheet.octopart.com:443/00-9276-004-021-106-AVX-datasheet-32784765.pdf?src-supplier=Newark; ComponentLink5Description: https://datasheet.octopart.com:443/009276004021106-AVX-datasheet-137053314.pdf?src-supplier=X-ON; ComponentLink5URL: https://datasheet.octopart.com:443/009276004021106-AVX-datasheet-137053314.pdf?src-supplier=X-ON; ComponentLink6Description: https://datasheet.octopart.com:443/009276004021106-AVX-datasheet-12539746.pdf?src-supplier=Future+Electronics; ComponentLink6URL: https://datasheet.octopart.com:443/009276004021106-AVX-datasheet-12539746.pdf?src-supplier=Future+Electronics; ComponentLink7Description: http://sigma.octopart.com:80/conflict_mineral_statement/AVX-company-34.pdf?src-supplier=Compliance+Statements; ComponentLink7URL: http://sigma.octopart.com:80/conflict_mineral_statement/AVX-company-34.pdf?src-supplier=Compliance+Statements; ComponentLink8Description: http://sigma.octopart.com:80/reach_statement/AVX-company-19.pdf?src-supplier=Compliance+Statements; ComponentLink8URL: http://sigma.octopart.com:80/reach_statement/AVX-company-19.pdf?src-supplier=Compliance+Statements; ComponentLink9Description: LCSC; ComponentLink9URL: https://www.lcsc.com/product-detail/C5852764.html; Contact Gender: Female; Contact Material: Bronze, Copper; Contact Plating: Tin; Depth: 9mm; Export Control Classification Number (ECCN) Code: EAR99; Harmonized Tariff Schedule (HTS) Code: 8536.69.40.30; Height: 5mm; Housing Color: White; Housing Material: Nylon; Introduction Date: 2010-10-28; Lead Free: Lead Free; Length: 9mm; Lifecycle Status: Production; Manufacturer Part Number: 009276004021106; Max Operating Temperature: 125°C; Min Operating Temperature: -40°C; Mount: Surface Mount; Number of Contacts: 4; Number of Pins: 4; Number of Positions: 4; Number of Positions Per Level: 4; Number of Rows: 1; Orientation: Right Angle; Packaging: Cut Tape; Pricing 1: 1=0.3629 (USD); Radiation Hardening: No; REACH SVHC: Yes; RoHS: Compliant; Stock 1: 6; Supplier: LCSC; Supplier 1: Arrow Electronics; Supplier Part Number: C5852764; Supplier Part Number 1: 009276004021106; Termination: Solder; Voltage: 250V; Voltage Rating: 250V; Width: 10mm; Library Name: josh-lib.SchLib
CONN4 = 781710005: Pico-EZmate Wire-to-Board Header Vertical Housing Height 1.55mm with Side Friction Locks 5 Circuits; Manufacturer: Molex; Manufacturer Lifecycle Status: ACTIVE; Manufacturer Part Number: 78171-0005; Contact Resistance: 20mΩ; Current Rating: 1.5A; Dielectric Material: Liquid Crystal Polymer; Gender: Male; Glow Wire Compliant: Non-compliant; Insulation Resistance: 100MΩ; Max Voltage Rating (AC): 50V; Number of Circuits: 4; Number of Contacts: 5; Pitch: 1.2mm; Plating: Gold; Plating Thickness: 101.6nm; Category: Headers and Wire Housings; China RoHS: Compliant; Color: Black; Component Kind: Standard; ComponentLink1Description: https://datasheet.octopart.com:443/78171-0005-Molex-datasheet-34442390.pdf?src-supplier=IHS; ComponentLink1URL: https://datasheet.octopart.com:443/78171-0005-Molex-datasheet-34442390.pdf?src-supplier=IHS; ComponentLink2Description: https://datasheet.octopart.com:443/78171-0005-Molex-datasheet-13786294.pdf?src-supplier=Sager+Electronics; ComponentLink2URL: https://datasheet.octopart.com:443/78171-0005-Molex-datasheet-13786294.pdf?src-supplier=Sager+Electronics; ComponentLink3Description: https://datasheet.octopart.com:443/0781710005-Molex-datasheet-156885141.pdf?src-supplier=Sager+Electronics; ComponentLink3URL: https://datasheet.octopart.com:443/0781710005-Molex-datasheet-156885141.pdf?src-supplier=Sager+Electronics; ComponentLink4Description: https://datasheet.octopart.com:443/781710005-Molex-datasheet-8335561.pdf?src-supplier=HQonline; ComponentLink4URL: https://datasheet.octopart.com:443/781710005-Molex-datasheet-8335561.pdf?src-supplier=HQonline; ComponentLink5Description: https://datasheet.octopart.com:443/78171-0005-Molex-datasheet-15500259.pdf?src-supplier=Molex; ComponentLink5URL: https://datasheet.octopart.com:443/78171-0005-Molex-datasheet-15500259.pdf?src-supplier=Molex; ComponentLink6Description: https://datasheet.octopart.com:443/78171-0005-Molex-datasheet-77522681.pdf?src-supplier=Farnell; ComponentLink6URL: https://datasheet.octopart.com:443/78171-0005-Molex-datasheet-77522681.pdf?src-supplier=Farnell; ComponentLink7Description: https://datasheet.octopart.com:443/78171-0005-Molex-datasheet-154759528.pdf?src-supplier=Master+Electronics; ComponentLink7URL: https://datasheet.octopart.com:443/78171-0005-Molex-datasheet-154759528.pdf?src-supplier=Master+Electronics; ComponentLink8Description: https://datasheet.octopart.com:443/78171-0005-Molex-datasheet-66628845.pdf?src-supplier=element14+APAC; ComponentLink8URL: https://datasheet.octopart.com:443/78171-0005-Molex-datasheet-66628845.pdf?src-supplier=element14+APAC; ComponentLink9Description: https://datasheet.octopart.com:443/78171-0005-Molex-datasheet-140188883.pdf?src-supplier=RS+(Formerly+Allied+Electronics); ComponentLink9URL: https://datasheet.octopart.com:443/78171-0005-Molex-datasheet-140188883.pdf?src-supplier=RS+(Formerly+Allied+Electronics); ComponentLink10Description: https://datasheet.octopart.com:443/78171-0005-Molex-datasheet-120157681.pdf?src-supplier=RS+(Formerly+Allied+Electronics); ComponentLink10URL: https://datasheet.octopart.com:443/78171-0005-Molex-datasheet-120157681.pdf?src-supplier=RS+(Formerly+Allied+Electronics); ComponentLink11Description: https://datasheet.octopart.com:443/78171-0005-Molex-datasheet-44403383.pdf?src-supplier=Electro+Sonic; ComponentLink11URL: https://datasheet.octopart.com:443/78171-0005-Molex-datasheet-44403383.pdf?src-supplier=Electro+Sonic; ComponentLink12Description: https://datasheet.octopart.com:443/78171-0005-Molex-datasheet-37172405.pdf?src-supplier=Electro+Sonic; ComponentLink12URL: https://datasheet.octopart.com:443/78171-0005-Molex-datasheet-37172405.pdf?src-supplier=Electro+Sonic; ComponentLink13Description: https://datasheet.octopart.com:443/78171-0005-Molex-datasheet-37100992.pdf?src-supplier=Electro+Sonic; ComponentLink13URL: https://datasheet.octopart.com:443/78171-0005-Molex-datasheet-37100992.pdf?src-supplier=Electro+Sonic; ComponentLink14Description: https://datasheet.octopart.com:443/78171-0005-Molex-datasheet-21209323.pdf?src-supplier=Newark; ComponentLink14URL: https://datasheet.octopart.com:443/78171-0005-Molex-datasheet-21209323.pdf?src-supplier=Newark; ComponentLink15Description: https://datasheet.octopart.com:443/78171-0005-Molex-datasheet-17587183.pdf?src-supplier=TME; ComponentLink15URL: https://datasheet.octopart.com:443/78171-0005-Molex-datasheet-17587183.pdf?src-supplier=TME; ComponentLink16Description: http://sigma.octopart.com:80/16453504/rohs_statement/Molex-78171-0005.pdf?src-supplier=Compliance+Statements; ComponentLink16URL: http://sigma.octopart.com:80/16453504/rohs_statement/Molex-78171-0005.pdf?src-supplier=Compliance+Statements; ComponentLink17Description: http://sigma.octopart.com:80/reach_statement/Molex-company-74.pdf?src-supplier=Compliance+Statements; ComponentLink17URL: http://sigma.octopart.com:80/reach_statement/Molex-company-74.pdf?src-supplier=Compliance+Statements; ComponentLink18Description: http://sigma.octopart.com:80/rohs_statement/Molex-company-73.pdf?src-supplier=Compliance+Statements; ComponentLink18URL: http://sigma.octopart.com:80/rohs_statement/Molex-company-73.pdf?src-supplier=Compliance+Statements; ComponentLink19Description: http://sigma.octopart.com:80/conflict_mineral_statement/Molex-company-72.pdf?src-supplier=Compliance+Statements; ComponentLink19URL: http://sigma.octopart.com:80/conflict_mineral_statement/Molex-company-72.pdf?src-supplier=Compliance+Statements; ComponentLink20Description: LCSC; ComponentLink20URL: https://www.lcsc.com/product-detail/C724177.html?s_z=n_781710005; ComponentLink21Description: DigiKey; ComponentLink21URL: https://www.digikey.com/en/products/detail/molex/0781710005/2424926; Contact Gender: Male; Contact Material: Copper; Contact Plating: Gold; Country of Origin: MY; Depth: 4.5mm; Export Control Classification Number (ECCN) Code: EAR99; Halogen Free: Low Halogen; Harmonized Tariff Schedule (HTS) Code: 8536.69.40.40; Housing Color: Black; Housing Material: Polymer; Introduction Date: 2009-12-11; Length: 7.8mm; Lifecycle Status: Production; Max Operating Temperature: 85°C; Min Operating Temperature: -25°C; Number of Pins: 5; Number of Positions: 5; Number of Rows: 1; Orientation: Vertical; Pricing 1: 4500=0.20888, 81000=0.19602, 202500=0.19502, 400500=0.19403, 1003500=0.19303 (USD); Radiation Hardening: No; REACH SVHC: Yes; RoHS: Compliant; Schedule B: 8536694040, 8536694040|8536694040|8536694040|8536694040|8536694040|8536694040|8536694040|8536694040|8536694040|8536694040|8536694040|8536694040|8536694040|8536694040|8536694040|8536694040|8536694040|8536694040|8536694040|8536694040|8536694040|8536694040|8536694040|85; Stock 1: 22500; Supplier: LCSC; Supplier 1: Avnet; Supplier Part Number: C724177; Supplier Part Number 1: 0781710005; Terminal Pitch: 1.2mm; Termination: SMD/SMT; Voltage Rating: 50V; Voltage Rating (AC): 50V; Weight: 37.222924mg; Library Name: josh-lib.SchLib
D1-D3 = DIODE SCHOTTKY 100V 2A DO220AA: Rectifier Diode, Schottky, 1 Phase, 1 Element, 2A, 100V V(RRM), Silicon, DO-220AA; Manufacturer: Vishay; Max Forward Surge Current (Ifsm): 50A; Max Reverse Current: 1uA; Case/Package: DO-220AA; Category: Rectifier Diodes; Component Kind: Standard; ComponentLink1Description: https://datasheet.ciiva.com/18567/ss2ph920&20ss2ph10-18567489.pdf?src-supplier=Digikey; ComponentLink1URL: https://datasheet.ciiva.com/18567/ss2ph920&20ss2ph10-18567489.pdf?src-supplier=Digikey; ComponentLink2Description: https://datasheet.ciiva.com/13948/ss2ph9-13948536.pdf?src-supplier=Digikey; ComponentLink2URL: https://datasheet.ciiva.com/13948/ss2ph9-13948536.pdf?src-supplier=Digikey; ComponentLink3Description: https://datasheet.ciiva.com/15045/ss2ph9ss2ph10-15045638.pdf?src-supplier=Digikey; ComponentLink3URL: https://datasheet.ciiva.com/15045/ss2ph9ss2ph10-15045638.pdf?src-supplier=Digikey; ComponentLink4Description: https://datasheet.ciiva.com/8289/ss2ph9-8289129.pdf?src-supplier=Avnet; ComponentLink4URL: https://datasheet.ciiva.com/8289/ss2ph9-8289129.pdf?src-supplier=Avnet; ComponentLink5Description: https://datasheet.ciiva.com/7962/ss2ph9-7962425.pdf?src-supplier=Element14; ComponentLink5URL: https://datasheet.ciiva.com/7962/ss2ph9-7962425.pdf?src-supplier=Element14; ComponentLink6Description: https://datasheet.ciiva.com/22695/1911775-22695932.pdf?src-supplier=Element14; ComponentLink6URL: https://datasheet.ciiva.com/22695/1911775-22695932.pdf?src-supplier=Element14; ComponentLink7Description: LCSC; ComponentLink7URL: https://www.lcsc.com/product-detail/Schottky-Diodes_Vishay-Intertech-SS2PH10-M3-84A_C77393.html; Forward Current: 2A; Height: 1.15mm; Lead Free: 5697LeadFree; Manufacturer Part Number: SS2PH10-M3/84A; Max Operating Temperature: 175°C; Max Reverse Voltage (DC): 100V; Max Surge Current: 50A; Min Operating Temperature: -55°C; Mount: Surface Mount; Number of Pins: 2; Package Quantity: 3000; Packaging: Tape and Reel; Peak Non-Repetitive Surge Current: 50A; Pricing 1: 1=0.45, 10=0.35, 100=0.21, 500=0.19442, 1000=0.13221, 3000=0.12171, 6000=0.11666, 9000=0.10499, 30000=0.10343, 75000=0.09721 (USD); Radiation Hardening: No; Reach SVHC Compliant: Unknown; Reverse Voltage: 100V; RoHS: Yes; RoHS Compliant: Yes; Series: eSMP®; Stock 1: 71131; Supplier: LCSC; Supplier 1: Digikey; Supplier Part Number: C77393; Supplier Part Number 1: SS2PH10-M3/84AGICT-ND; Library Name: josh-lib.SchLib
D5 = LESD5D5.0CT1G_Leiditech: ESD DIODE 5VWM 12VC SOD-523 bi-directional; Clamp Voltage: 12V; Component Kind: Standard; ComponentLink1Description: LCSC; ComponentLink1URL: https://www.lcsc.com/product-detail/C5246195.html?s_z=n_C5246195; Manufacturer: Leiditech; Supplier: LCSC; Supplier Part Number: C5246195; Library Name: josh-lib.SchLib
D6 D7 = LESD5D5.0CT1G_Leiditech: ESD DIODE 5VWM 12VC SOD-523 bi-directional; Clamp Voltage: 12V; Vrwm: 5V; Component Kind: Standard; ComponentLink1Description: LCSC; ComponentLink1URL: https://www.lcsc.com/product-detail/C5246195.html?s_z=n_C5246195; Manufacturer: Leiditech; Manufacturer Part Number: LESD5D5.0CT1G; Supplier: LCSC; Supplier Part Number: C5246195; Library Name: josh-lib.SchLib
D8 = SMAJ54A-13-F: SMAJ Series 54 V 400 W Surface Mount Uni-Directional TVS Diode - DO-214AC; Manufacturer: Diodes; Breakdown Voltage: 60V; Clamping Voltage: 87.1V; Clamping Voltage-Max: 38.9V; Diode Type: ZENER; Direction: Unidirectional; Leakage Current: 5uA; Max Breakdown Voltage: 29.5V; Max Power Dissipation: 400W; Max Surge Current: 40A; Number of Elements: 1; Peak Pulse Current: 4.6A; Power Line Protection: No; Test Current: 1mA; Case/Package: SMA; Category: TVS Diodes; Component Kind: Standard; ComponentLink1Description: http://components.arrow.com/part/dataview/41509896S7614698N2867?src-supplier=Arrow+Electronics; ComponentLink1URL: http://components.arrow.com/part/dataview/41509896S7614698N2867?src-supplier=Arrow+Electronics; ComponentLink2Description: http://www.mouser.com/ds/2/115/ds19005-73607.pdf?src-supplier=Mouser; ComponentLink2URL: http://www.mouser.com/ds/2/115/ds19005-73607.pdf?src-supplier=Mouser; ComponentLink3Description: https://www.diodes.com/assets/Datasheets/ds19005.pdf?src-supplier=Digikey; ComponentLink3URL: https://www.diodes.com/assets/Datasheets/ds19005.pdf?src-supplier=Digikey; ComponentLink4Description: http://www.diodes.com/datasheets/ds19005.pdf?src-supplier=Avnet; ComponentLink4URL: http://www.diodes.com/datasheets/ds19005.pdf?src-supplier=Avnet; ComponentLink5Description: http://www.diodes.com/_files/datasheets/ds19005.pdf?src-supplier=Future+Electronics; ComponentLink5URL: http://www.diodes.com/_files/datasheets/ds19005.pdf?src-supplier=Future+Electronics; ComponentLink6Description: https://datasheet.octopart.com/SMAJ54A-13-F-Diodes-Inc.-datasheet-62305222.pdf?src-supplier=Future+Electronics; ComponentLink6URL: https://datasheet.octopart.com/SMAJ54A-13-F-Diodes-Inc.-datasheet-62305222.pdf?src-supplier=Future+Electronics; ComponentLink7Description: https://datasheet.octopart.com/SMAJ54A-13-F-Diodes-Inc.-datasheet-157073627.pdf?src-supplier=Newark; ComponentLink7URL: https://datasheet.octopart.com/SMAJ54A-13-F-Diodes-Inc.-datasheet-157073627.pdf?src-supplier=Newark; ComponentLink8Description: https://datasheet.octopart.com/SMAJ54A-13-F-Diodes-Inc.-datasheet-157073627.pdf?src-supplier=TME; ComponentLink8URL: https://datasheet.octopart.com/SMAJ54A-13-F-Diodes-Inc.-datasheet-157073627.pdf?src-supplier=TME; ComponentLink9Description: LCSC; ComponentLink9URL: https://www.lcsc.com/product-detail/ESD-and-Surge-Protection-TVS-ESD_Diodes-Incorporated-SMAJ54A-13-F_C134996.html; Lead Free: Lead Free; Length: 4.6mm; Manufacturer Part Number: SMAJ54A-13-F; Max Operating Temperature: 150°C; Max Reverse Leakage Current: 5uA; Min Breakdown Voltage: 60V; Min Operating Temperature: -55°C; Mount: Surface Mount; Number of Pins: 2; Number of Unidirectional Channels: 1; Package Quantity: 5000; Packaging: Tape and Reel; Peak Pulse Power: 400W; Polarity: Unidirectional; Power Dissipation: 400W; Pricing 1: 1=0.29, 10=0.197, 100=0.093, 500=0.088, 1000=0.083 (USD); Reverse Breakdown Voltage: 60V; Reverse Standoff Voltage: 54V; RoHS: Yes; RoHS Compliant: Yes; Series: -; Stock 1: 1498; Supplier: LCSC; Supplier 1: Digikey; Supplier Part Number: C134996; Supplier Part Number 1: 31-SMAJ54A-13-FCT-ND; Termination: SMD/SMT; Working Voltage: 54V; Library Name: josh-lib.SchLib
L1 L2 = CKCS8040-120uH/M: 950mA 120uH 334mΩ 1.12A Magnetic Shielded Inductor ±20% SMD,8x8mm Fixed Inductors RoHS; Manufacturer: CENKER; Component Kind: Standard; ComponentLink1Description: LCSC; ComponentLink1URL: https://www.lcsc.com/product-detail/C5291902.html; Isat: 1.12A; Manufacturer Part Number: CKCS8040-120uH/M; Supplier: LCSC; Supplier Part Number: C5291902; Value: 120uH; Library Name: josh-lib.SchLib
L3 = NR6045T330M: FIXED IND 33UH 1.4A 188.5 MOHM; Manufacturer: Taiyo Yuden; Core Material: Ferrite; Inductance Tolerance: 20%; Self Resonant Frequency: 6MHz; Shielding: Shielded; Test Frequency: 100kHz; Case/Package: Nonstandard; Component Kind: Standard; ComponentLink1Description: https://www.yuden.co.jp/productdata/catalog/wound04_e.pdf?src-supplier=Digi-Key; ComponentLink1URL: https://www.yuden.co.jp/productdata/catalog/wound04_e.pdf?src-supplier=Digi-Key; ComponentLink2Description: http://www.yuden.co.jp/productdata/catalog/en/wound04_e.pdf?src-supplier=Digi-Key; ComponentLink2URL: http://www.yuden.co.jp/productdata/catalog/en/wound04_e.pdf?src-supplier=Digi-Key; ComponentLink3Description: http://www.yuden.co.jp/or/product/category/inductor/NR6045T330M.pdf?src-supplier=Avnet; ComponentLink3URL: http://www.yuden.co.jp/or/product/category/inductor/NR6045T330M.pdf?src-supplier=Avnet; ComponentLink4Description: LCSC; ComponentLink4URL: https://www.lcsc.com/product-detail/Power-Inductors_Taiyo-Yuden-NR6045T330M_C92942.html; Composition: Wirewound; Current Rating: 1.4A; Depth: 6.2mm; Halogen Free: Halogen Free; Height: 4.5mm; Height - Seated (Max): 0.177inch; Inductance: 33uH; Length: 0.236inch; Manufacturer Part Number: NR6045T330M; Max Operating Temperature: 120°C; Min Operating Temperature: -25°C; Mount: Surface Mount; Number of Pins: 2; Package Quantity: 1500; Packaging: Tape and Reel; Pricing 1: 1 = 0.44000, 10 = 0.38200, 100 = 0.27310, 500 = 0.22942 (USD); Radiation Hardening: No; REACH SVHC: Unknown; RMS Current (Irms): 1.4A; RoHS: Yes; Saturation Current: 1.5A; Stock 1: 26538; Supplier: LCSC; Supplier 1: Digi-Key; Supplier Part Number: C92942; Supplier Part Number 1: 587-2634-1-ND; Termination: SMD/SMT; Tolerance: 20%; Width: 0.236inch; Library Name: josh-lib.SchLib
R1 R3 = R0402 3K01 1% 63mW: Thick Film Resistors - SMD RMC 0402 1/16W 1% T/R-10000; Manufacturer: Royal Ohm; Manufacturer Part Number: 0402WGF3011TCE; Resistance: 3.01kΩ; Category: Uncategorized; Component Kind: Standard; ComponentLink1Description: https://datasheet.octopart.com:443/0402WGF3011TCE-Royal-Ohm-datasheet-165785611.pdf?src-supplier=TME; ComponentLink1URL: https://datasheet.octopart.com:443/0402WGF3011TCE-Royal-Ohm-datasheet-165785611.pdf?src-supplier=TME; ComponentLink2Description: https://datasheet.octopart.com:443/0402WGF3011TCE-Royal-Ohm-datasheet-157855877.pdf?src-supplier=TME; ComponentLink2URL: https://datasheet.octopart.com:443/0402WGF3011TCE-Royal-Ohm-datasheet-157855877.pdf?src-supplier=TME; ComponentLink3Description: LCSC; ComponentLink3URL: https://www.lcsc.com/product-detail/C25889.html; Pricing 1: 10000=0.004 (USD); Stock 1: 30000; Supplier: LCSC; Supplier 1: Mouser; Supplier Part Number: C25889; Supplier Part Number 1: 303-0402WGF3011TCE; Library Name: josh-lib.SchLib
R2 R4 = R0402 20K 1% 63mW: 20kΩ ±1% 62.5mW 0402 Thick Film Resistor; Manufacturer: UNI-ROYAL; Manufacturer Part Number: 0402WGF2002TCE; Component Kind: Standard; ComponentLink1Description: LCSC; ComponentLink1URL: https://www.lcsc.com/product-detail/C25765.html?s_z=n_0402WGF2002TCE; Supplier: LCSC; Supplier Part Number: C25765; Value: 20k; Library Name: josh-lib.SchLib
R5 = AC0402FR-07118KL: Res Thick Film 0402 118K Ohm 1% 0.063W(1/16W) ±100ppm/C Pad SMD Automotive T/R; Manufacturer: Yageo; Max Power Dissipation: 63mW; Resistance: 118kR; Temperature Coefficient: 100ppm/°C; Voltage Rating: 50V; Case/Package: 0402; Category: Chip SMD Resistors; Component Kind: Standard; ComponentLink1Description: http://www.yageo.com/NewPortal/yageodocoutput?fileName=/pdf/R-Chip/PYu-AC_51_RoHS_L_6.pdf&src-supplier=Digikey; ComponentLink1URL: http://www.yageo.com/NewPortal/yageodocoutput?fileName=/pdf/R-Chip/PYu-AC_51_RoHS_L_6.pdf&src-supplier=Digikey; ComponentLink2Description: https://datasheet.octopart.com/AC0402FR-07118KL-Yageo-datasheet-166720450.pdf?src-supplier=Future+Electronics; ComponentLink2URL: https://datasheet.octopart.com/AC0402FR-07118KL-Yageo-datasheet-166720450.pdf?src-supplier=Future+Electronics; ComponentLink3Description: LCSC; ComponentLink3URL: https://www.lcsc.com/product-detail/Chip-Resistor-Surface-Mount_YAGEO-AC0402FR-07118KL_C144804.html; Features: Moisture Resistant,Automotive AEC-Q200; Height: 0.015inch; Length: 0.039inch; Manufacturer Part Number: AC0402FR-07118KL; Max Operating Temperature: 155°C; Min Operating Temperature: -55°C; Number of Terminations: 2; Packaging: Tape and Reel; Pricing 1: 1=0.1, 10=0.01, 50=0.0086, 100=0.0071, 500=0.00664, 1000=0.00612 (USD); RoHS: Yes; RoHS Compliant: Yes; Series: AC; Stock 1: 77; Supplier: LCSC; Supplier 1: Digikey; Supplier Part Number: C144804; Supplier Part Number 1: 13-AC0402FR-07118KLCT-ND; Tolerance: 1%; Width: 0.02inch; Library Name: josh-lib.SchLib
